# Altium schematic sheet Sheet1.SchDoc(Sheet1)
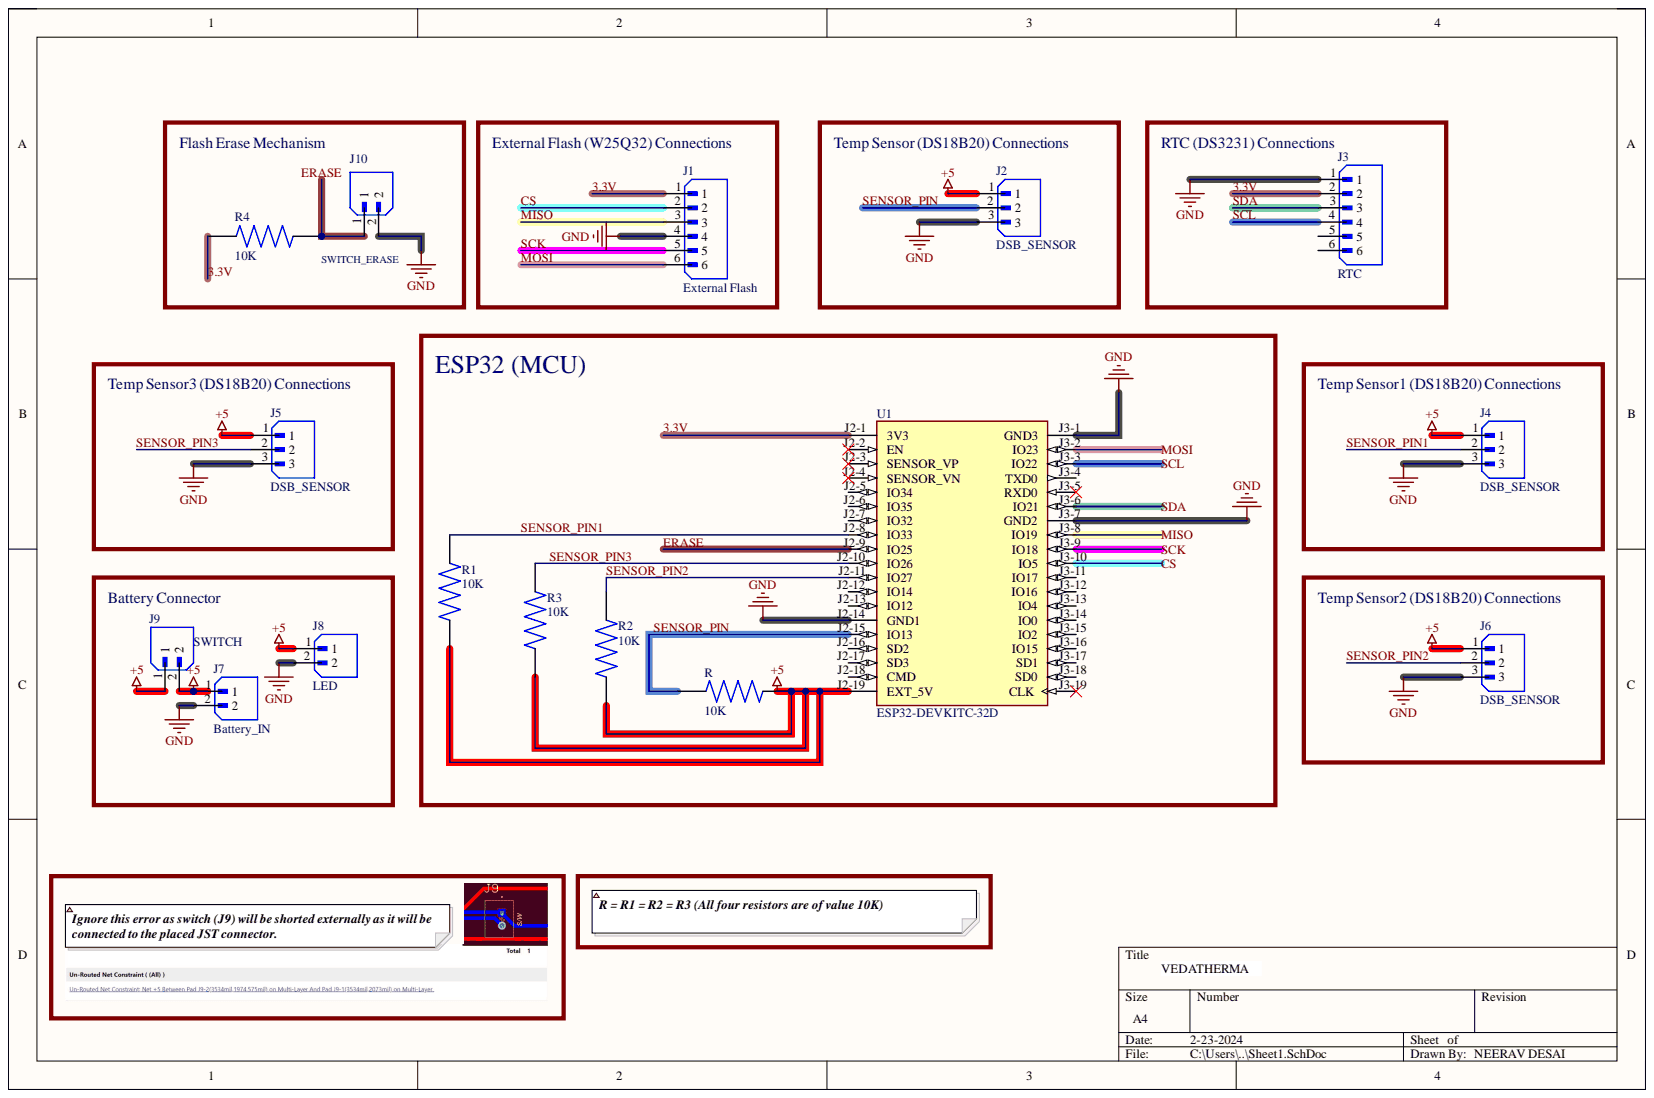
[diagram p0: Sheet1.SchDoc]

## Parts
J1  External Flash  [JST_B6B-XH-A(LF)(SN)]
J2  DSB_SENSOR  [JST_B3B-XH-A(LF)(SN)]
J3  RTC  [JST_B6B-XH-A(LF)(SN)]
J4  DSB_SENSOR  [JST_B3B-XH-A(LF)(SN)]
J5  DSB_SENSOR  [JST_B3B-XH-A(LF)(SN)]
J6  DSB_SENSOR  [JST_B3B-XH-A(LF)(SN)]
J7  Battery_IN  [JST_B2B-XH-A(LF)(SN)]
J8  LED  [JST_B2B-XH-A(LF)(SN)]
J9  SWITCH  [JST_B2B-XH-A(LF)(SN)]
J10  SWITCH_ERASE  [JST_B2B-XH-A(LF)(SN)]
R  10K  [RES_ERA8ARW103V]
R1  10K  [RES_ERA8ARW103V]
R2  10K  [RES_ERA8ARW103V]
R3  10K  [RES_ERA8ARW103V]
R4  10K  [RES_ERA8ARW103V]
U1  ESP32-DEVKITC-32D  [MODULE_ESP32-DEVKITC-32D]

## Nets
+5: J2.1 J4.1 J5.1 J6.1 J7.1 J8.1 J9.1 J9.2 R.1 R1.2 R2.2 R3.2 U1.J2-19(EXT_5V)
GND: J1.4 J2.3 J3.1 J4.3 J5.3 J6.3 J7.2 J8.2 J10.2 U1.J2-14(GND1) U1.J3-1(GND3) U1.J3-7(GND2)
3.3V: J1.1 J3.2 R4.1 U1.J2-1(3V3)
CS: J1.2 U1.J3-10(IO5)
ERASE: J10.1 R4.2 U1.J2-9(IO25)
MISO: J1.3 U1.J3-8(IO19)
MOSI: J1.6 U1.J3-2(IO23)
SCK: J1.5 U1.J3-9(IO18)
SCL: J3.4 U1.J3-3(IO22)
SDA: J3.3 U1.J3-6(IO21)
SENSOR_PIN: J2.2 R.2 U1.J2-15(IO13)
SENSOR_PIN1: J4.2 R1.1 U1.J2-8(IO33)
SENSOR_PIN2: J6.2 R2.1 U1.J2-11(IO27)
SENSOR_PIN3: J5.2 R3.1 U1.J2-10(IO26)

Not connected: J3 5 6; U1 J2-2(EN) J2-3(SENSOR_VP) J2-4(SENSOR_VN) J2-5(IO34) J2-6(IO35) J2-7(IO32) J2-12(IO14) J2-13(IO12) J2-16(SD2) J2-17(SD3) J2-18(CMD) J3-4(TXD0) J3-5(RXD0) J3-11(IO17) J3-12(IO16) J3-13(IO4) J3-14(IO0) J3-15(IO2) J3-16(IO15) J3-17(SD1) J3-18(SD0) J3-19(CLK)

## Library data of the parts
J1 J3 = B6B-XH-A(LF)(SN): Connector Header Through Hole 6 position 0.098 (2.50mm); MF: JST Sales; Check_prices: https://www.snapeda.com/parts/B6B-XH-A(LF)(SN)/JST+Sales+America+Inc./view-part/?ref=eda; Component Kind: Standard; Library Name: JST_6PIN.IntLib; MP: B6B-XH-A(LF)(SN); Package: None; SnapEDA_Link: https://www.snapeda.com/parts/B6B-XH-A(LF)(SN)/JST+Sales+America+Inc./view-part/?ref=snap
J2 J4-J6 = B3B-XH-A(LF)(SN): Connector Header Through Hole 3 position 0.098 (2.50mm); MF: JST Sales; Check_prices: https://www.snapeda.com/parts/B3B-XH-A(LF)(SN)/JST+Sales+America+Inc./view-part/?ref=eda; Component Kind: Standard; Library Name: JST_3PIN.IntLib; MP: B3B-XH-A(LF)(SN); Package: None; SnapEDA_Link: https://www.snapeda.com/parts/B3B-XH-A(LF)(SN)/JST+Sales+America+Inc./view-part/?ref=snap
J7-J10 = B2B-XH-A(LF)(SN): Connector Header Through Hole 2 position 0.098 (2.50mm); MF: JST Sales America Inc.; Check_prices: https://www.snapeda.com/parts/B2B-XH-A(LF)(SN)/JST+Sales+America+Inc./view-part/?ref=eda; Component Kind: Standard; Library Name: JST_2PIN.IntLib; MP: B2B-XH-A(LF)(SN); Package: None; SnapEDA_Link: https://www.snapeda.com/parts/B2B-XH-A(LF)(SN)/JST+Sales+America+Inc./view-part/?ref=snap
R R1-R4 = ERA8ARW103V: Availability: Unavailable; Component Kind: Standard; Library Name: RESISTOR_10k_1206.IntLib; MP: ERA8ARW103V; Package: None; Price: None
U1 = ESP32-DEVKITC-32D: WiFi Development Tools (802.11) ESP32 General Development Kit, ESP32-WROOM-32D on the board; MF: Espressif Systems; Check_prices: https://www.snapeda.com/parts/ESP32-DEVKITC-32D/Espressif+Systems/view-part/?ref=eda; Component Kind: Standard; Library Name: ESP32-DEVKITC-32D.IntLib; MP: ESP32-DEVKITC-32D; Package: None; SnapEDA_Link: https://www.snapeda.com/parts/ESP32-DEVKITC-32D/Espressif+Systems/view-part/?ref=snap
